# Altium schematic sheet Gate_Driver.SchDoc
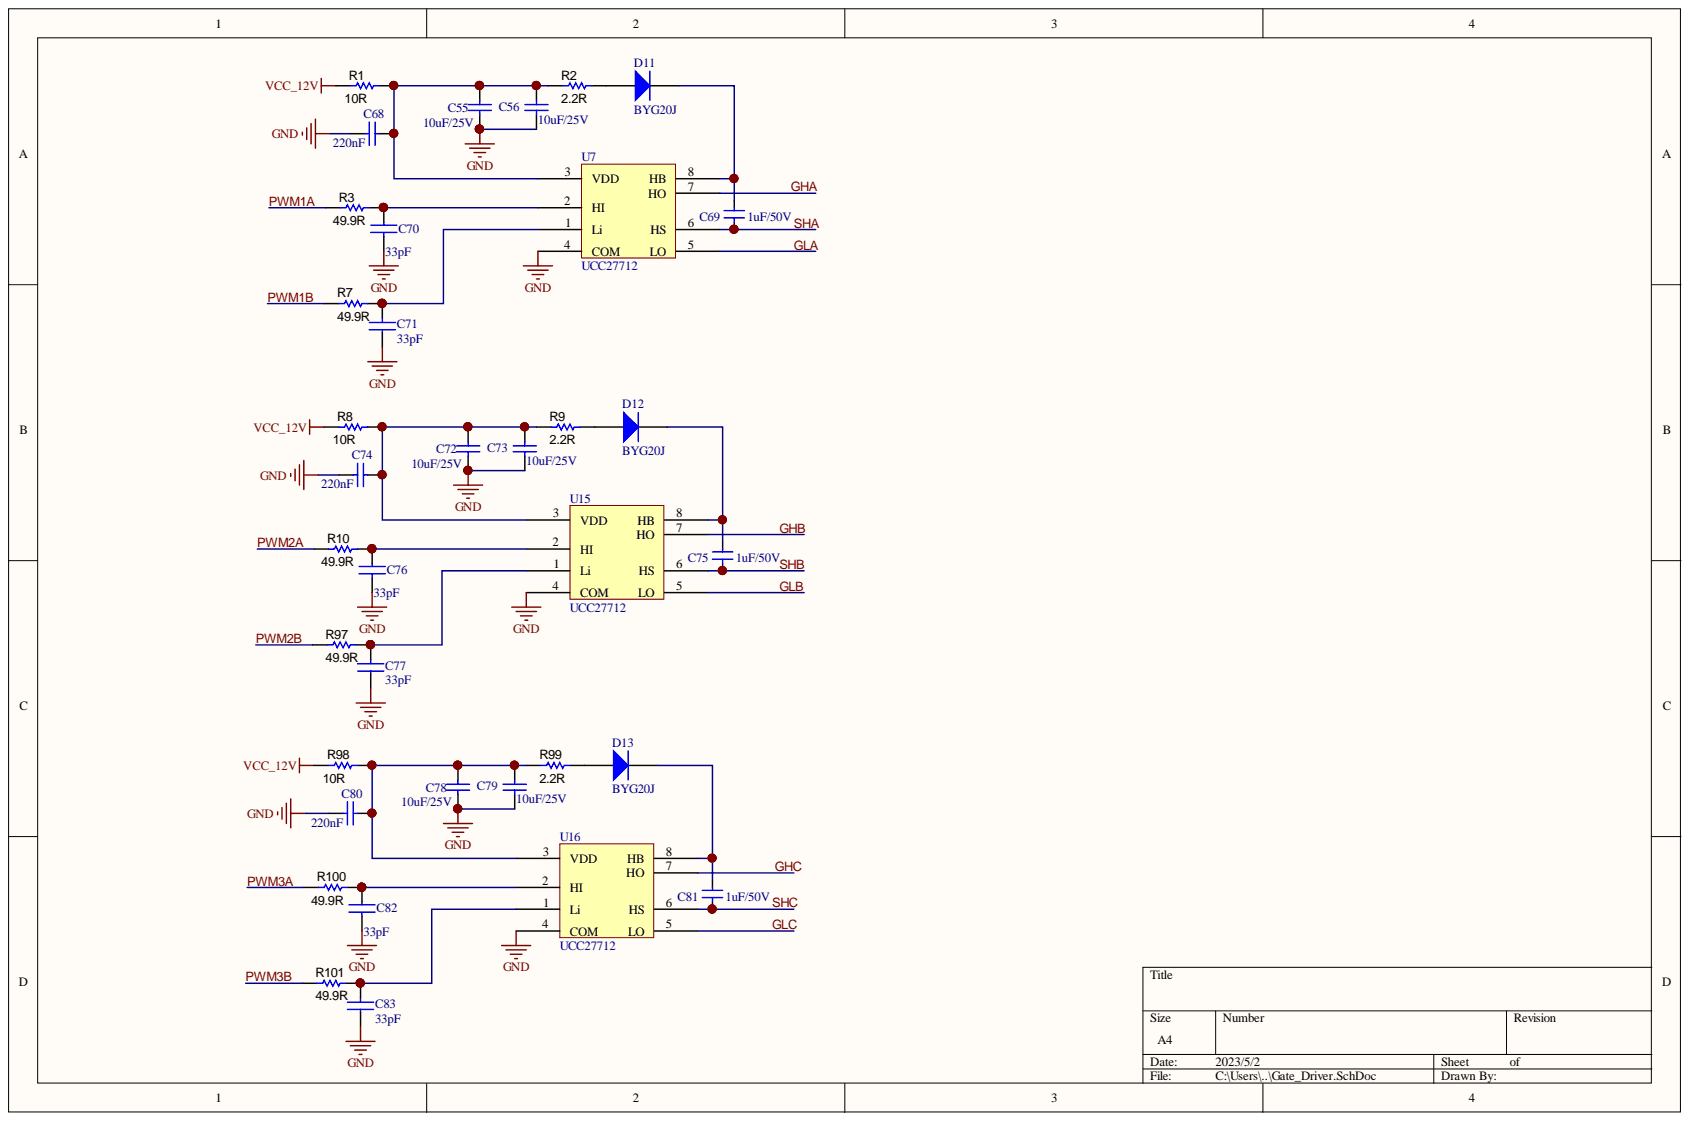
[diagram p0: Gate_Driver.SchDoc]

## Parts
C55  10uF/25V  [1206]
C56  10uF/25V  [1206]
C68  220nF  [0603]
C69  1uF/50V  [1206]
C70  33pF  [0603]
C71  33pF  [0603]
C72  10uF/25V  [1206]
C73  10uF/25V  [1206]
C74  220nF  [0603]
C75  1uF/50V  [1206]
C76  33pF  [0603]
C77  33pF  [0603]
C78  10uF/25V  [1206]
C79  10uF/25V  [1206]
C80  220nF  [0603]
C81  1uF/50V  [1206]
C82  33pF  [0603]
C83  33pF  [0603]
D11  BYG20J  [DO-214AA]
D12  BYG20J  [DO-214AA]
D13  BYG20J  [DO-214AA]
R1  10R  [0603]
R2  2.2R  [0603]
R3  49.9R  [0603]
R7  49.9R  [0603]
R8  10R  [0603]
R9  2.2R  [0603]
R10  49.9R  [0603]
R97  49.9R  [0603]
R98  10R  [0603]
R99  2.2R  [0603]
R100  49.9R  [0603]
R101  49.9R  [0603]
U7  UCC27712  [SOIC8_3.90MM]
U15  UCC27712  [SOIC8_3.90MM]
U16  UCC27712  [SOIC8_3.90MM]

## Nets
GND: C55.1 C56.1 C68.2 C70.2 C71.1 C72.1 C73.1 C74.2 C76.2 C77.1 C78.1 C79.1 C80.2 C82.2 C83.1 U7.4(COM) U15.4(COM) U16.4(COM)
VCC_12V: R1.2 R8.2 R98.2
GHA: U7.7(HO)
GHB: U15.7(HO)
GHC: U16.7(HO)
GLA: U7.5(LO)
GLB: U15.5(LO)
GLC: U16.5(LO)
PWM1A: R3.1
PWM1B: R7.1
PWM2A: R10.1
PWM2B: R97.1
PWM3A: R100.1
PWM3B: R101.1
SHA: C69.2 U7.6(HS)
SHB: C75.2 U15.6(HS)
SHC: C81.2 U16.6(HS)
NetC55_2: C55.2 C56.2 C68.1 R1.1 R2.2 U7.3(VDD)
NetC69_1: C69.1 D11.2 U7.8(HB)
NetC70_1: C70.1 R3.2 U7.2(HI)
NetC71_2: C71.2 R7.2 U7.1(Li)
NetC72_2: C72.2 C73.2 C74.1 R8.1 R9.2 U15.3(VDD)
NetC75_1: C75.1 D12.2 U15.8(HB)
NetC76_1: C76.1 R10.2 U15.2(HI)
NetC77_2: C77.2 R97.2 U15.1(Li)
NetC78_2: C78.2 C79.2 C80.1 R98.1 R99.2 U16.3(VDD)
NetC81_1: C81.1 D13.2 U16.8(HB)
NetC82_1: C82.1 R100.2 U16.2(HI)
NetC83_2: C83.2 R101.2 U16.1(Li)
NetD11_1: D11.1 R2.1
NetD12_1: D12.1 R9.1
NetD13_1: D13.1 R99.1

## Library data of the parts
C55 C56 C68 C69 C72-C75 C78-C81 = Cap: Component Kind: Standard
  C55 C56 C68 C72-C74 C78-C80: LatestRevisionDate: 17-Jul-2002; LatestRevisionNote: Re-released for DXP Platform.; Library Name: XDS100V3.SCHLIB; PackageReference: RAD-0.3; Published: 8-Jun-2000; Signal Integrity: Cap; Simulation: CAP; Publisher: Altium Limited
C70 C71 C76 C77 C82 C83 = Component-CAP: User Parameter 1: foot; Component Kind: Standard; Library Name: AltiumDesigner_Lib-tony.SchLib
D11-D13 = Diode 1N4007: Code_JEDEC: DO-204-AL; Component Kind: Standard; ComponentLink1Description: Manufacturer Link; ComponentLink1URL: http://www.fairchildsemi.com/; ComponentLink2Description: Datasheet; ComponentLink2URL: http://www.fairchildsemi.com/ds/1N%2F1N4001.pdf; DatasheetDocument: 1998; LatestRevisionDate: 17-Jul-2002; LatestRevisionNote: Re-released for DXP Platform.; Library Name: Miscellaneous Devices.IntLib; PackageDocument: Aug-1999; PackageReference: DO-41; Published: 8-Jun-2000; Publisher: Altium Limited
R1 R2 R8 R9 R98 R99 = RG1608P-4991-B-T5: Manufacturer: Susumu Co Ltd; CompType: Resistor; EIA_Code: E192; Power_W: 0.1; Res_ohm: 4990; TempCo: 25 ppm/C; U/M: EA; Value: 4.99k; Value1: 0.1%; Value2: 0.1W; Value_Code: 4k99; VDC_V: 75; Component Kind: Standard; ComponentLink1Description: Datasheet link; ComponentLink1URL: http://www.susumu.co.jp/english/pdf/products-j01-01.pdf; LatestRevisionDate: 2014-05-20; Library Name: Resistor_0603.IntLib; MountType: SMT; PackageReference: 0603; PartNumber: RG1608P-4991-B-T5; Published: 2008-06-26; RoHS: Y; Signal Integrity: Resistor; Simulation: Resistor; Supplier 1: Digi-Key; Supplier 2: Mouser; Supplier Part Number 1: RG16P4.99KBCT-ND; Supplier Part Number 2: 754-RG1608P-4991-BT5; SymbolVersion: 2009-05-29; Technology: Metal Film; Tolerance_%: 0.1; Publisher: Texas Instruments
R3 R7 R10 R97 R100 R101 = CRCW060310R0FKEA: Manufacturer: Vishay-Dale; CompType: Resistor; EIA_Code: E96; Power_W: 0.1; Res_ohm: 10; TempCo: 100 ppm/C; Tolerance_%: 1; U/M: EA; Value: 10.0; Value1: 1%; Value2: 0.1W; Value_Code: 10R0; VDC_V: 75; Component Kind: Standard; ComponentLink1Description: Datasheet link; ComponentLink1URL: http://www.vishay.com/docs/20035/dcrcwe3.pdf; LatestRevisionDate: 2014-05-20; Library Name: Resistor_0603.IntLib; MountType: SMT; PackageReference: 0603; PartNumber: CRCW060310R0FKEA; Published: 2008-06-26; RoHS: Y; Signal Integrity: Resistor; Simulation: Resistor; Supplier 1: Digi-Key; Supplier 2: Mouser; Supplier 3: Farnell; Supplier Part Number 1: 541-10.0HCT-ND; Supplier Part Number 2: 71-CRCW0603-10-E3; Supplier Part Number 3: 1469751; SymbolVersion: 2009-05-29; Technology: Thick Film; Publisher: Texas Instruments
U7 U15 U16 = TI-UCC27712: Component Kind: Standard; Library Name: AltiumDesigner_Lib-syc.SchLib
